# Altium schematic sheet QSPI_Norflash.SchDoc
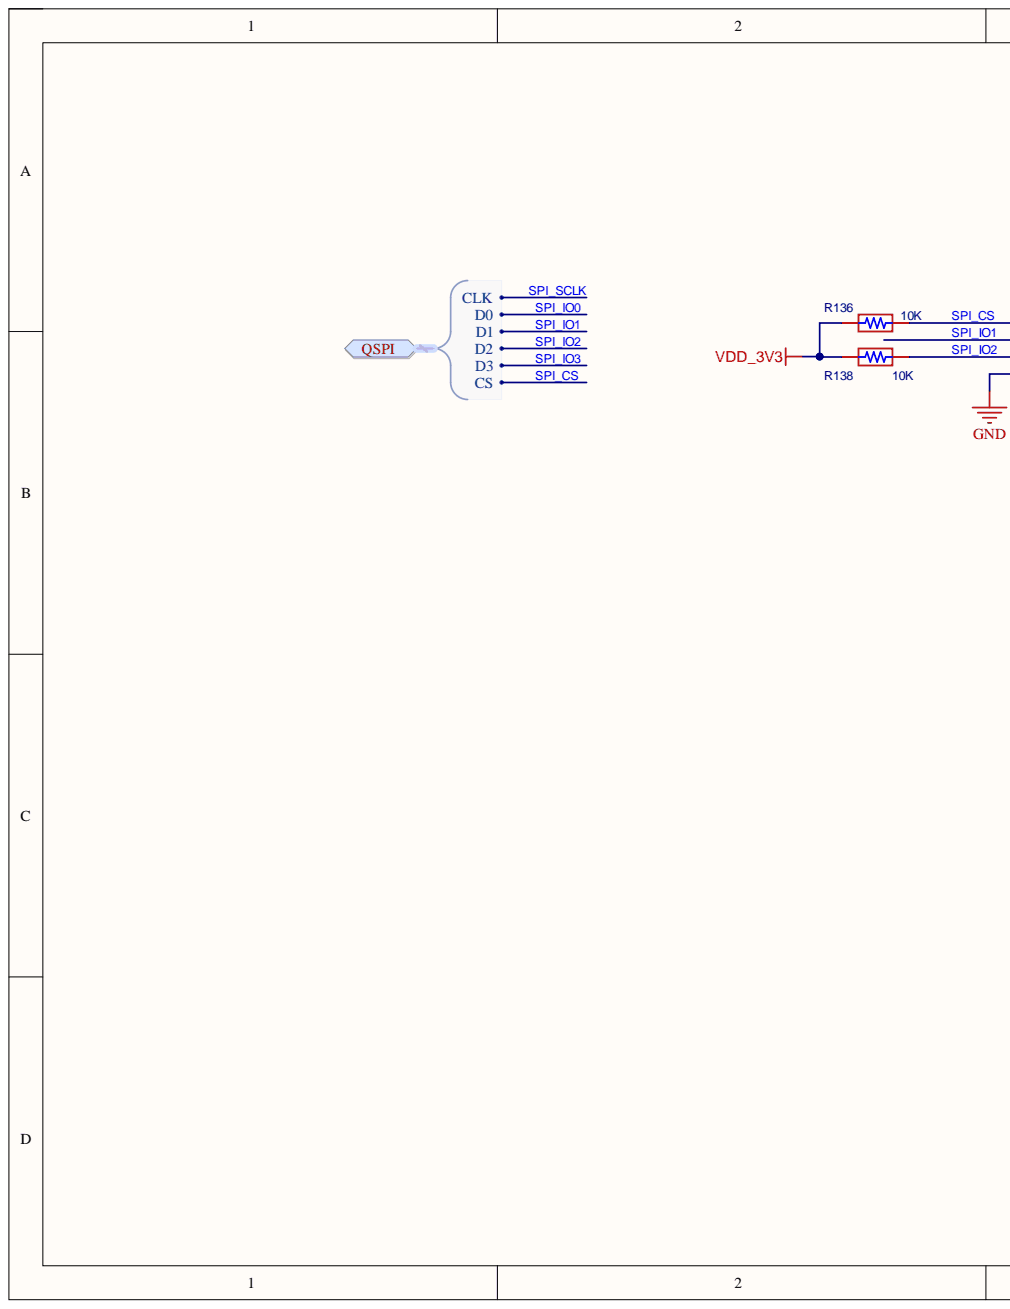
[diagram p0: QSPI_Norflash.SchDoc, left]
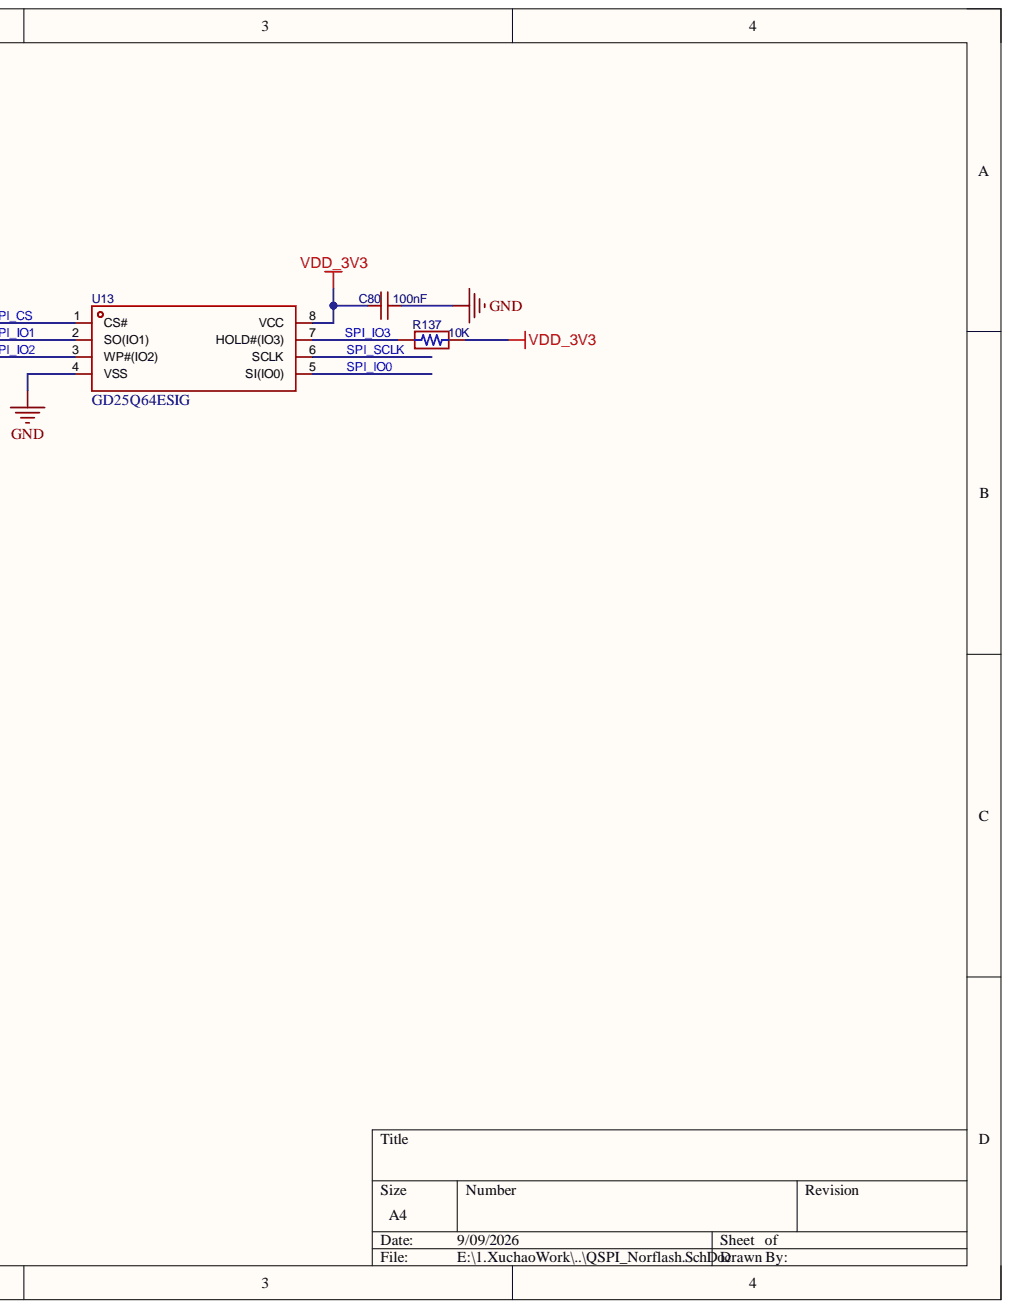
[diagram p1: QSPI_Norflash.SchDoc, right]

## Parts
C80  100nF  [C0402]
R136  10K  [R0402]
R137  10K  [R0402]
R138  10K  [R0402]
U13  GD25Q64ESIG  [SOP-8_L5.3-W5.3-P1.27-LS8.0-BL]

## Ports
QSPI, QSPI.CLK, QSPI.CS, QSPI.D0, QSPI.D1, QSPI.D2, QSPI.D3

## Nets
GND: C80.1 U13.4(VSS)
VDD_3V3: C80.2 R136.2 R137.2 R138.2 U13.8(VCC)
SPI_CS: R136.1 U13.1(CS#) ; port QSPI
SPI_IO0: U13.5(SI(IO0)) ; port QSPI
SPI_IO1: U13.2(SO(IO1)) ; port QSPI
SPI_IO2: R138.1 U13.3(WP#(IO2)) ; port QSPI
SPI_IO3: R137.1 U13.7(HOLD#(IO3)) ; port QSPI
SPI_SCLK: U13.6(SCLK) ; port QSPI

## Library data of the parts
C80 = 0SMD_CAP: Symbol: CL10A106KP8NNNC; Component Kind: Standard; Library Name: CYKJ_LibPkg.IntLib
R136-R138 = Resisteors: RES_SMD; Component Kind: Standard; Library Name: CYKJ_library.SchLib
U13 = IC_FLASH_GD25Q64ESIG: norflash; Component Kind: Standard; Symbol: GD25Q64ESIG; Library Name: CYKJ_LibPkg.IntLib
